# Altium schematic sheet Top.SchDoc
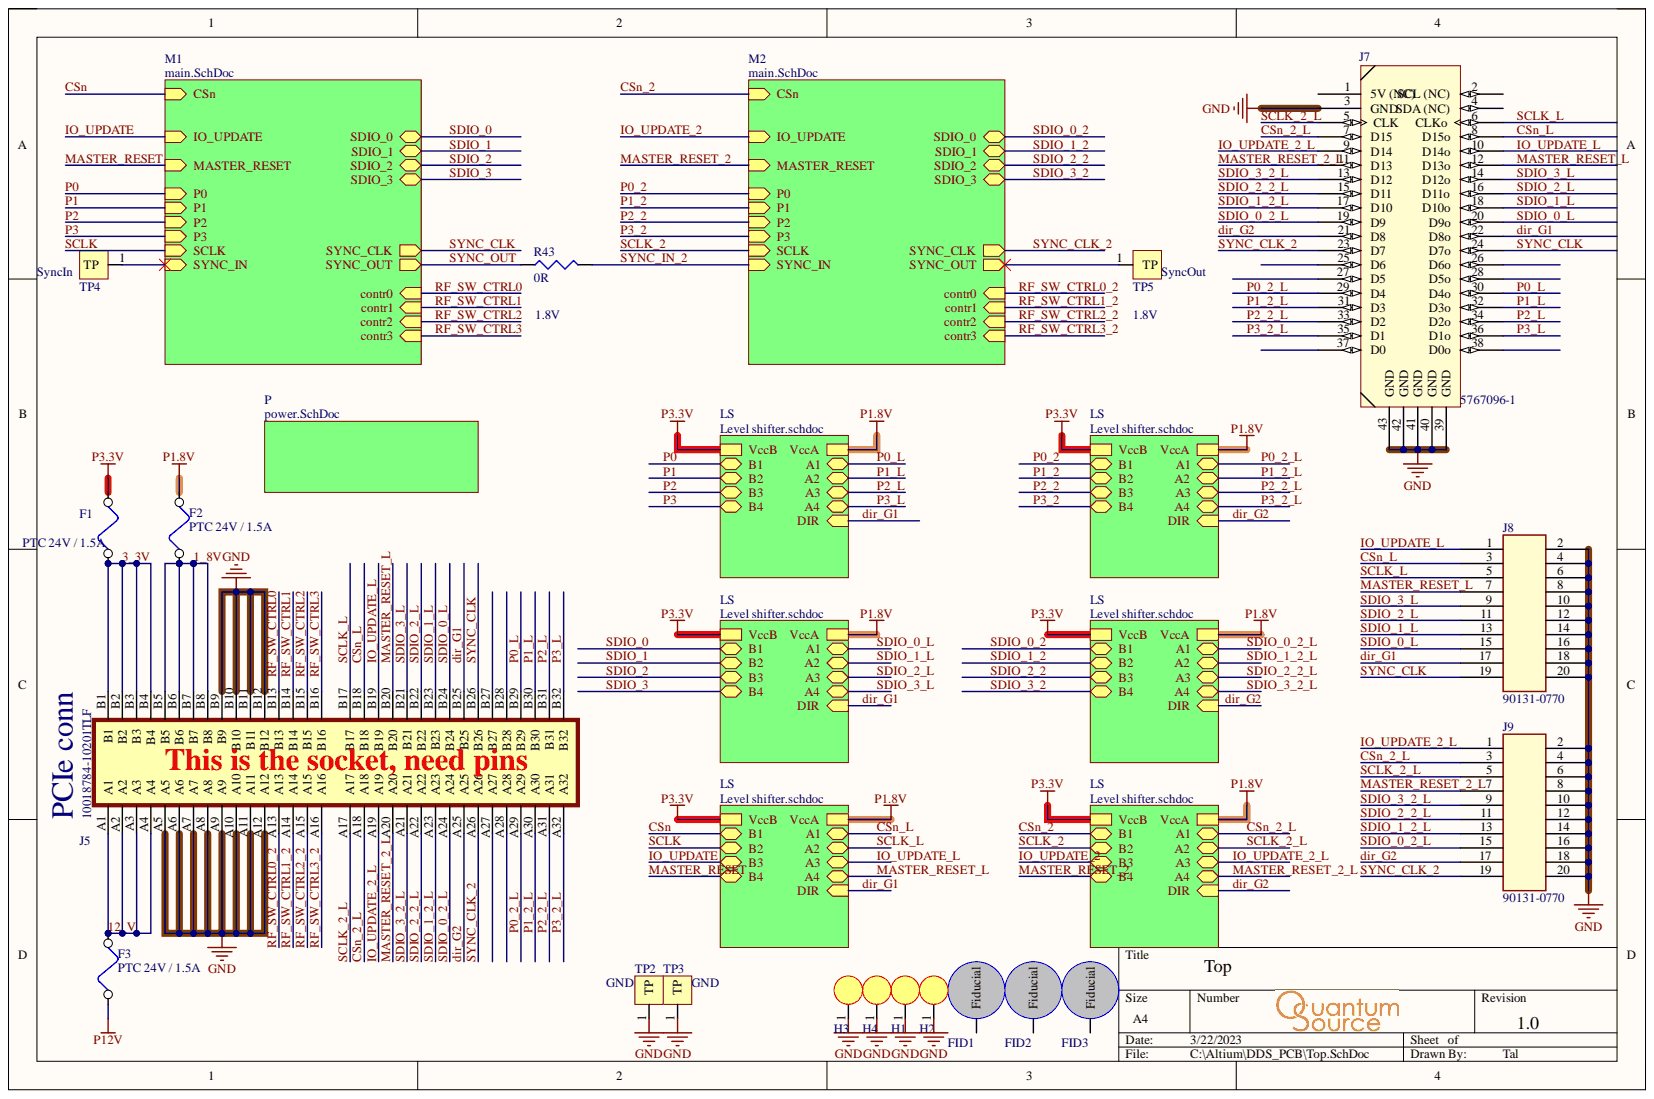
[diagram p0: Top.SchDoc]

## Parts
F1  PTC 24V / 1.5A  Current - Hold (Ih) (Max): 1.5A  [1812_F]
F2  PTC 24V / 1.5A  [1812_F]
F3  PTC 24V / 1.5A  [1812_F]
FID1  Fiducial  [Fiducial]
FID2  Fiducial  [Fiducial]
FID3  Fiducial  [Fiducial]
H1  Hole 3mm  [hole 3mm]  (value not drawn)
H2  Hole 3mm  [hole 3mm]  (value not drawn)
H3  Hole 3mm  [hole 3mm]  (value not drawn)
H4  Hole 3mm  [hole 3mm]  (value not drawn)
J5  10018784-10201TLF  [CONN_10018784-10201TLF]
J7  5767096-1  [MICTOR-38_PIN]
J8  90131-0770  [HEADER_10x2]
J9  90131-0770  [HEADER_10x2]
R43  0R  [R0603]
TP2  GND  [TP_2x1.8]
TP3  GND  [TP_2x1.8]
TP4  SyncIn  [TP_2x1.8]
TP5  SyncOut  [TP_2x1.8]

## Nets
GND: H1.1 H2.1 H3.1 H4.1 J5.A5 J5.A6 J5.A7 J5.A8 J5.A9 J5.A10 J5.A11 J5.A12 J5.B9 J5.B10 J5.B11 J5.B12 J7.3(GND) J7.39(GND) J7.40(GND) J7.41(GND) J7.42(GND) J7.43(GND) J8.2 J8.4 J8.6 J8.8 J8.10 J8.12 J8.14 J8.16 J8.18 J8.20 J9.2 J9.4 J9.6 J9.8 J9.10 J9.12 J9.14 J9.16 J9.18 J9.20 TP2.1 TP3.1
P1.8V: F2.2
P3.3V: F1.2
P12V: F3.1
1_8V: F2.1 J5.B5 J5.B6 J5.B7 J5.B8
3_3V: F1.1 J5.B1 J5.B2 J5.B3 J5.B4
12_V: F3.2 J5.A1 J5.A2 J5.A3 J5.A4
CSn_2_L: J5.A18 J7.7(D15) J9.3
CSn_L: J5.B18 J7.8(D15o) J8.3
dir_G1: J5.B25 J7.22(D8o) J8.17
dir_G2: J5.A25 J7.21(D8) J9.17
IO_UPDATE_2_L: J5.A19 J7.9(D14) J9.1
IO_UPDATE_L: J5.B19 J7.10(D14o) J8.1
MASTER_RESET_2_L: J5.A20 J7.11(D13) J9.7
MASTER_RESET_L: J5.B20 J7.12(D13o) J8.7
P0_2_L: J5.A29 J7.29(D4)
P0_L: J5.B29 J7.30(D4o)
P1_2_L: J5.A30 J7.31(D3)
P1_L: J5.B30 J7.32(D3o)
P2_2_L: J5.A31 J7.33(D2)
P2_L: J5.B31 J7.34(D2o)
P3_2_L: J5.A32 J7.35(D1)
P3_L: J5.B32 J7.36(D1o)
RF_SW_CTRL0: J5.B13
RF_SW_CTRL0_2: J5.A13
RF_SW_CTRL1: J5.B14
RF_SW_CTRL1_2: J5.A14
RF_SW_CTRL2: J5.B15
RF_SW_CTRL2_2: J5.A15
RF_SW_CTRL3: J5.B16
RF_SW_CTRL3_2: J5.A16
SCLK_2_L: J5.A17 J7.5(CLK) J9.5
SCLK_L: J5.B17 J7.6(CLKo) J8.5
SDIO_0_2_L: J5.A24 J7.19(D9) J9.15
SDIO_0_L: J5.B24 J7.20(D9o) J8.15
SDIO_1_2_L: J5.A23 J7.17(D10) J9.13
SDIO_1_L: J5.B23 J7.18(D10o) J8.13
SDIO_2_2_L: J5.A22 J7.15(D11) J9.11
SDIO_2_L: J5.B22 J7.16(D11o) J8.11
SDIO_3_2_L: J5.A21 J7.13(D12) J9.9
SDIO_3_L: J5.B21 J7.14(D12o) J8.9
SYNC_CLK: J5.B26 J7.24(D7o) J8.19
SYNC_CLK_2: J5.A26 J7.23(D7) J9.19
SYNC_IN_2: R43.2
SYNC_OUT: R43.1
NetFID1_1: FID1.1
NetFID2_1: FID2.1
NetFID3_1: FID3.1
NetJ5_A27: J5.A27
NetJ5_A28: J5.A28
NetJ5_B27: J5.B27
NetJ5_B28: J5.B28
NetJ7_1: J7.1(5V_(NC))
NetJ7_2: J7.2
NetJ7_4: J7.4
NetJ7_25: J7.25(D6)
NetJ7_26: J7.26(D6o)
NetJ7_27: J7.27(D5)
NetJ7_28: J7.28(D5o)
NetJ7_37: J7.37(D0)
NetJ7_38: J7.38(D0o)
NetTP4_1: TP4.1
NetTP5_1: TP5.1
CSn:
CSn_2:
IO_UPDATE:
IO_UPDATE_2:
MASTER_RESET:
MASTER_RESET_2:
P0:
P0_2:
P1:
P1_2:
P2:
P2_2:
P3:
P3_2:
SCLK:
SCLK_2:
SDIO_0:
SDIO_0_2:
SDIO_1:
SDIO_1_2:
SDIO_2:
SDIO_2_2:
SDIO_3:
SDIO_3_2:

## Library data of the parts
F1-F3 = 1812L150/24MR: FUSE PTC 24V 1.5A POLYFUSE 1812; Manufacturer: Littelfuse Inc; LANDA P/N: EFS-000011; Component Kind: Standard; ComponentLink1Description: http://www.littelfuse.com/data/en/Data_Sheets/Littelfuse_PTC_1812L.pdf; ComponentLink1URL: http://www.littelfuse.com/data/en/Data_Sheets/Littelfuse_PTC_1812L.pdf; Manufacturer Part Number: 1812L150/24MR; Package / Case: 1812 (4532 Metric), Concave; RoHS: RoHS Compliant; Library Name: DPB_spliter.SCHLIB
  F2 F3: Current - Hold (Ih) (Max): 1.5A
FID1-FID3 = Fiducial: Assembly: NA; Component Kind: Standard; Library Name: DPB_spliter.SCHLIB
J5 = 10018784-10201TLF: 64 Position Female Connector PCI Expressâ„¢ Gold 0.039 _1.00mm_ Black; MF: Amphenol ICC; Availability: Unavailable; Component Kind: Standard; Library Name: 10018784-10201TLF.IntLib; MP: 10018784-10201TLF; Package: None; Price: None; SnapEDA_Link: https://www.snapeda.com/parts/10018784-10201TLF/Amphenol%20FCI/view-part/2598016/?ref=snap
J7 = 5767096-1: CONN MICTOR 38POS .025 VERT SMD; Manufacturer: TE Connectivity; LANDA P/N: ECN-000060; Component Kind: Standard; ComponentLink1Description: http://www.te.com/commerce/DocumentDelivery/DDEController?Action=srchrtrv&DocNm=5767096&DocType=Customer+Drawing&DocLang=English; ComponentLink1URL: http://www.te.com/commerce/DocumentDelivery/DDEController?Action=srchrtrv&DocNm=5767096&DocType=Customer+Drawing&DocLang=English; Library Name: L_SCH_J_Z.SchLib; Manufacturer Part Number: 5767096-1; RoHS: RoHS Compliant
J8 J9 = 90131-0770: CONN HEADER 20 TH; Manufacturer: Molex Connector Corporation; LANDA P/N: ECN-000005; Component Kind: Standard; ComponentLink1Description: http://www.molex.com/pdm_docs/sd/901310770_sd.pdf; ComponentLink1URL: http://www.molex.com/pdm_docs/sd/901310770_sd.pdf; Contact Type: Male Pin; Library Name: L_SCH_J_Z.SchLib; Manufacturer Part Number: 90131-0770; Mounting Type: Through Hole; RoHS: RoHS Compliant
R43 = RES 0 OHM 1/10W 0603 SMD: Manufacturer: Yageo; Manufacturer Part Number: RC0603JR-070RL; Assembly: N.C; LANDA P/N: ERS-000001; Power (Watts): 0.1W, 1/10W; Component Kind: Standard; ComponentLink1Description: http://www.yageo.com/documents/recent/PYu-RC0603_51_RoHS_L_4.pdf; ComponentLink1URL: http://www.yageo.com/documents/recent/PYu-RC0603_51_RoHS_L_4.pdf; Description: RES 0 OHM 1/10W 0603 SMD; Library Name: L_SCH_R.SchLib; Package / Case: 0603 (1608 Metric); RoHS: RoHS Compliant; Supplier Device Package: 0603
TP2 TP4 TP5 = TP mini_2: TP PC MINIATURE SMT; Manufacturer: Keystone Electronics; Manufacturer Part Number: 5015; Assembly: N.A; LANDA P/N: ECN-000032; Component Kind: Standard; RoHS: RoHS Compliant; Library Name: DPB_spliter.SCHLIB
TP3 = TP mini_1: TP PC MINIATURE SMT; Manufacturer: Keystone Electronics; Manufacturer Part Number: 5015; Assembly: N.A; LANDA P/N: ECN-000032; Component Kind: Standard; RoHS: RoHS Compliant; Library Name: DPB_spliter.SCHLIB
H1-H4 = Hole 3mm: Component Kind: Standard; Description: hole 3mm; Library Name: L_SCH_Misc.SchLib
